# Altium schematic sheet cpu.SchDoc(cpu)
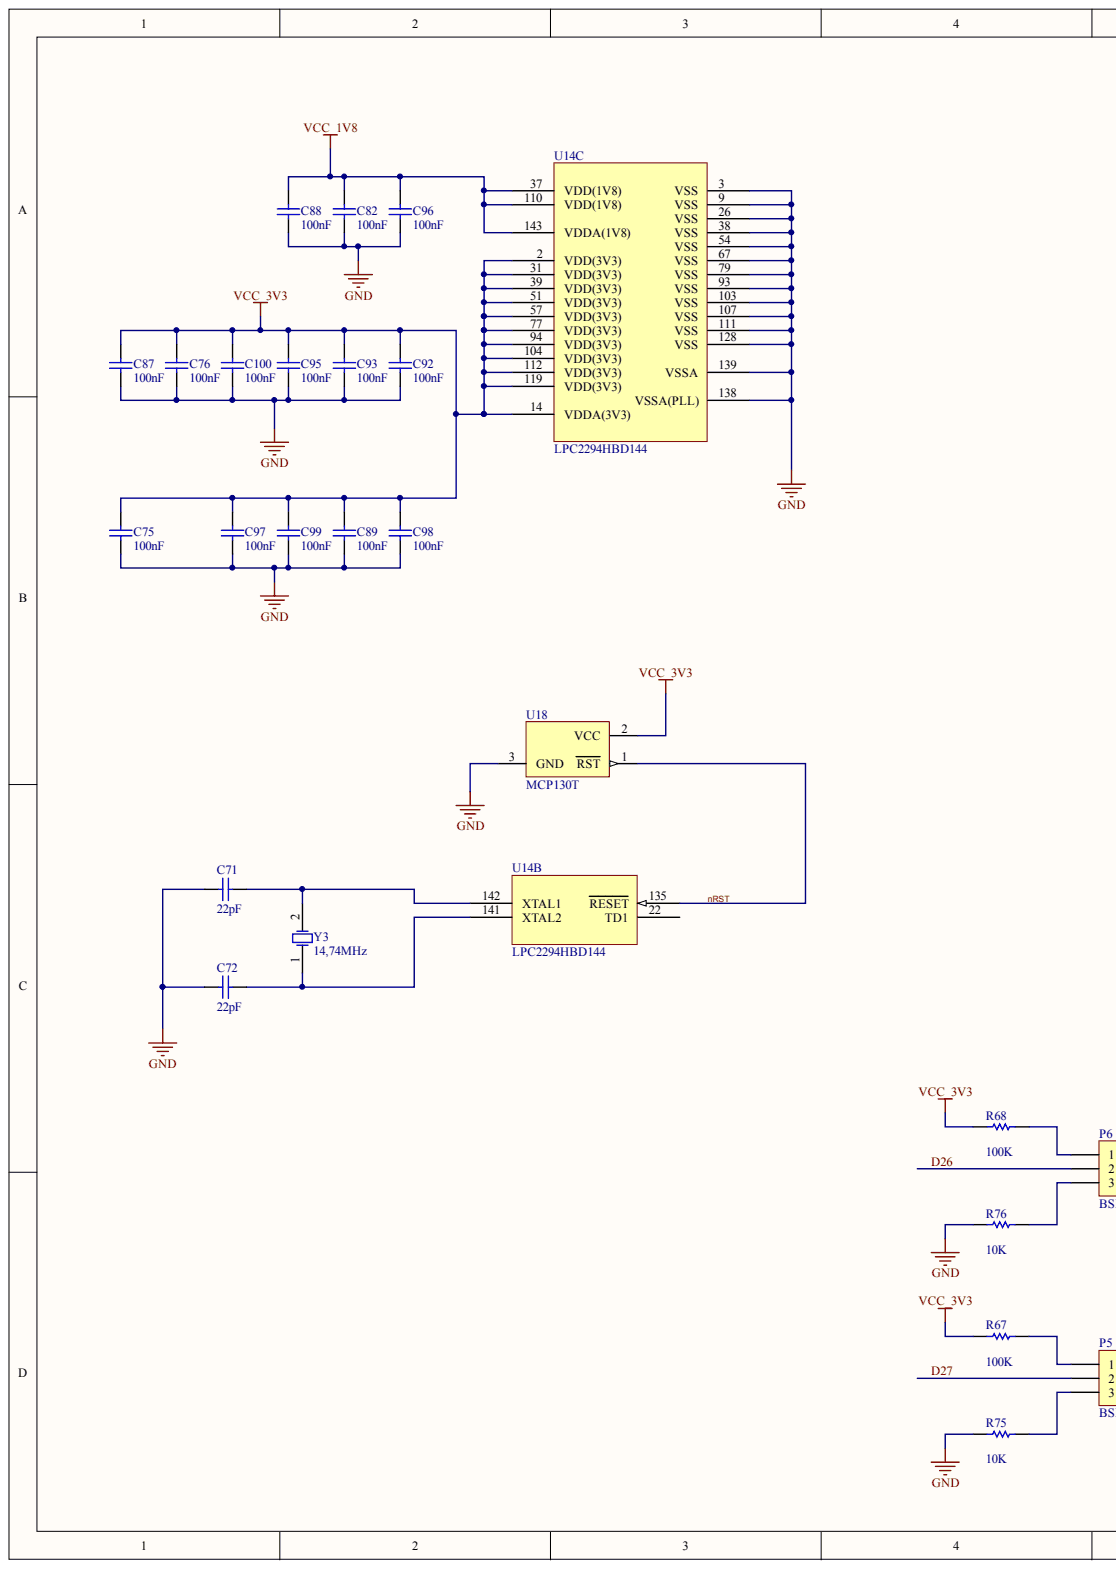
[diagram p0: cpu.SchDoc, left]
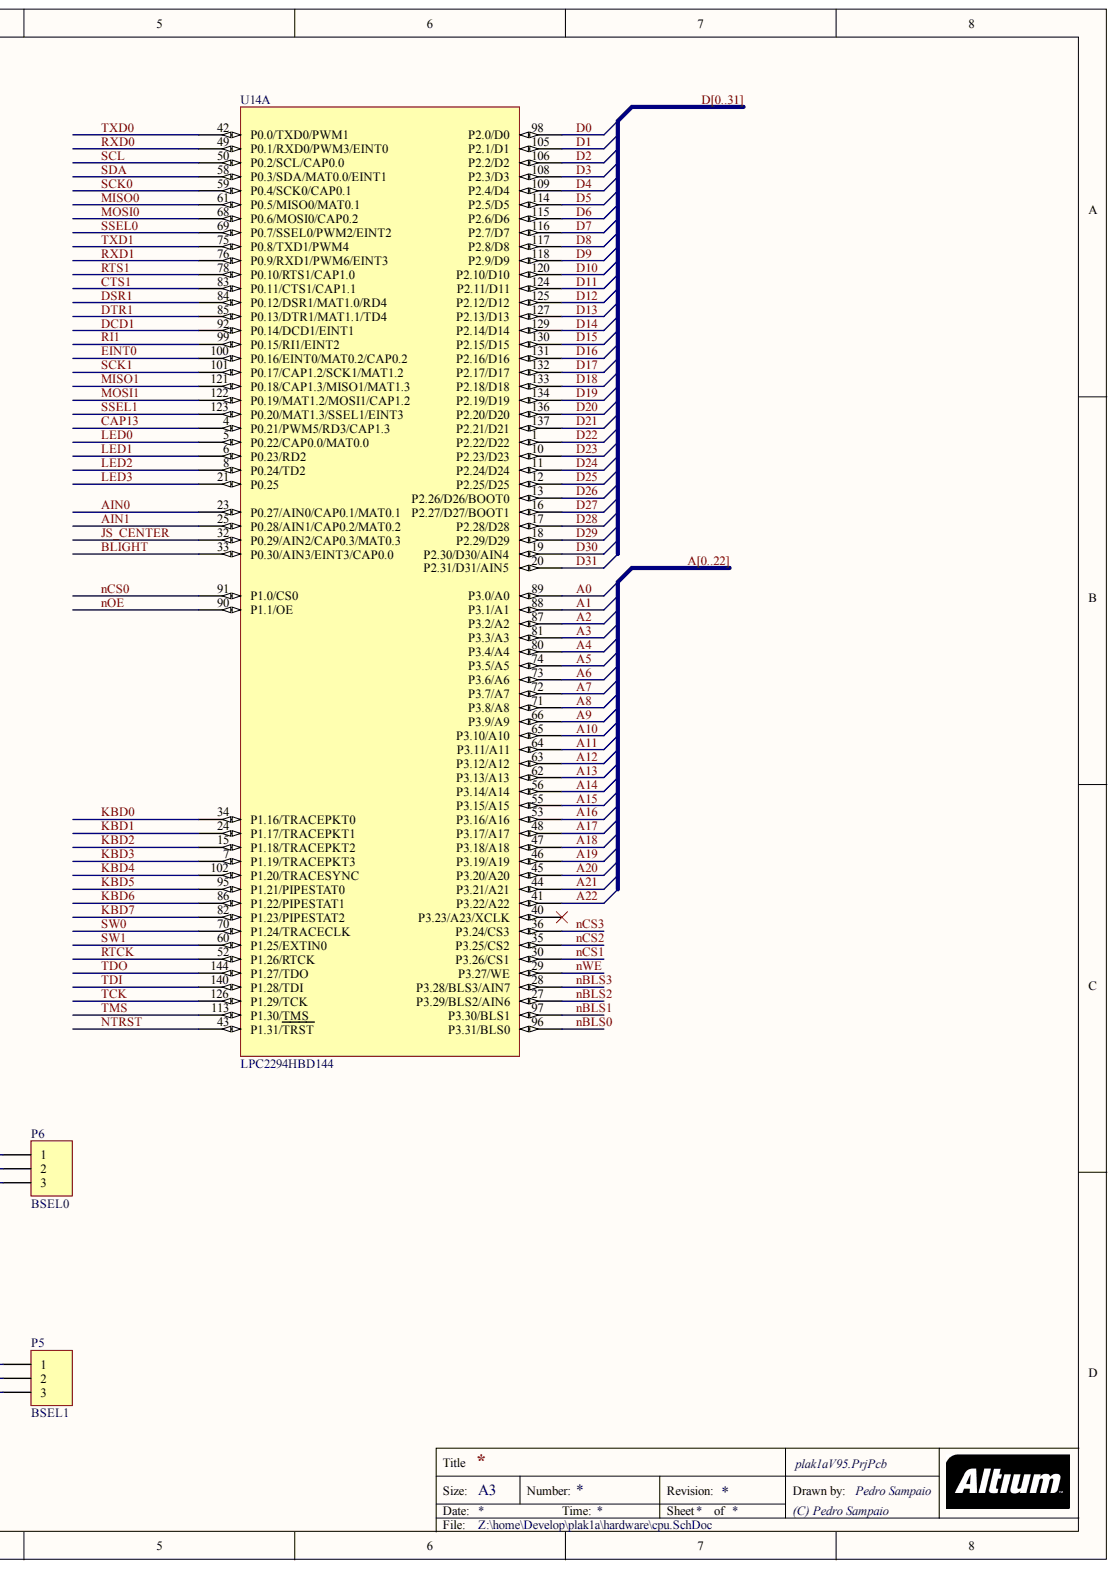
[diagram p1: cpu.SchDoc, right]

## Parts
C71  value 22pF  [0805]  (hidden comment: C0805)
C72  value 22pF  [0805]  (hidden comment: C0805)
C75  value 100nF  [0603]  (hidden comment: C0603)
C76  value 100nF  [0603]  (hidden comment: C0603)
C82  value 100nF  [0603]  (hidden comment: C0603)
C87  value 100nF  [0603]  (hidden comment: C0603)
C88  value 100nF  [0603]  (hidden comment: C0603)
C89  value 100nF  [0603]  (hidden comment: C0603)
C92  value 100nF  [0603]  (hidden comment: C0603)
C93  value 100nF  [0603]  (hidden comment: C0603)
C95  value 100nF  [0603]  (hidden comment: C0603)
C96  value 100nF  [0603]  (hidden comment: C0603)
C97  value 100nF  [0603]  (hidden comment: C0603)
C98  value 100nF  [0603]  (hidden comment: C0603)
C99  value 100nF  [0603]  (hidden comment: C0603)
C100  value 100nF  [0603]  (hidden comment: C0603)
P5  BSEL1  [HDR1X3]
P6  BSEL0  [HDR1X3]
R67  value 100K  [3216[1206]]  (hidden comment: Res Semi)
R68  value 100K  [3216[1206]]  (hidden comment: Res Semi)
R75  value 10K  [3216[1206]]  (hidden comment: Res Semi)
R76  value 10K  [3216[1206]]  (hidden comment: Res Semi)
U14  LPC2294HBD144  [SOT486-1_N]  (parts A,B,C)
U18  MCP130T  [SOT223]
Y3  14,74MHz  [CS10]

## Nets
GND: C71.1 C72.1 C75.1 C76.1 C82.1 C87.1 C88.1 C89.1 C92.1 C93.1 C95.1 C96.1 C97.1 C98.1 C99.1 C100.1 R75.1 R76.1 U14.3(VSS) U14.9(VSS) U14.26(VSS) U14.38(VSS) U14.54(VSS) U14.67(VSS) U14.79(VSS) U14.93(VSS) U14.103(VSS) U14.107(VSS) U14.111(VSS) U14.128(VSS) U14.138(VSSA(PLL)) U14.139(VSSA) U18.3
VCC_1V8: C82.2 C88.2 C96.2 U14.37(VDD(1V8)) U14.110(VDD(1V8)) U14.143(VDDA(1V8))
VCC_3V3: C75.2 C76.2 C87.2 C89.2 C92.2 C93.2 C95.2 C97.2 C98.2 C99.2 C100.2 R67.1 R68.1 U14.2(VDD(3V3)) U14.14(VDDA(3V3)) U14.31(VDD(3V3)) U14.39(VDD(3V3)) U14.51(VDD(3V3)) U14.57(VDD(3V3)) U14.77(VDD(3V3)) U14.94(VDD(3V3)) U14.104(VDD(3V3)) U14.112(VDD(3V3)) U14.119(VDD(3V3)) U18.2
A0: U14.89(P3.0/A0)  [also labelled A]
A1: U14.88(P3.1/A1)  [also labelled A]
A2: U14.87(P3.2/A2)  [also labelled A]
A3: U14.81(P3.3/A3)  [also labelled A]
A4: U14.80(P3.4/A4)  [also labelled A]
A5: U14.74(P3.5/A5)  [also labelled A]
A6: U14.73(P3.6/A6)  [also labelled A]
A7: U14.72(P3.7/A7)  [also labelled A]
A8: U14.71(P3.8/A8)  [also labelled A]
A9: U14.66(P3.9/A9)  [also labelled A]
A10: U14.65(P3.10/A10)  [also labelled A]
A11: U14.64(P3.11/A11)  [also labelled A]
A12: U14.63(P3.12/A12)  [also labelled A]
A13: U14.62(P3.13/A13)  [also labelled A]
A14: U14.56(P3.14/A14)  [also labelled A]
A15: U14.55(P3.15/A15)  [also labelled A]
A16: U14.53(P3.16/A16)  [also labelled A]
A17: U14.48(P3.17/A17)  [also labelled A]
A18: U14.47(P3.18/A18)  [also labelled A]
A19: U14.46(P3.19/A19)  [also labelled A]
A20: U14.45(P3.20/A20)  [also labelled A]
A21: U14.44(P3.21/A21)  [also labelled A]
A22: U14.41(P3.22/A22)  [also labelled A]
AIN0: U14.23(P0.27/AIN0/CAP0.1/MAT0.1)
AIN1: U14.25(P0.28/AIN1/CAP0.2/MAT0.2)
BLIGHT: U14.33(P0.30/AIN3/EINT3/CAP0.0)
CAP13: U14.4(P0.21/PWM5/RD3/CAP1.3)
CTS1: U14.83(P0.11/CTS1/CAP1.1)
D0: U14.98(P2.0/D0)  [also labelled D]
D1: U14.105(P2.1/D1)  [also labelled D]
D2: U14.106(P2.2/D2)  [also labelled D]
D3: U14.108(P2.3/D3)  [also labelled D]
D4: U14.109(P2.4/D4)  [also labelled D]
D5: U14.114(P2.5/D5)  [also labelled D]
D6: U14.115(P2.6/D6)  [also labelled D]
D7: U14.116(P2.7/D7)  [also labelled D]
D8: U14.117(P2.8/D8)  [also labelled D]
D9: U14.118(P2.9/D9)  [also labelled D]
D10: U14.120(P2.10/D10)  [also labelled D]
D11: U14.124(P2.11/D11)  [also labelled D]
D12: U14.125(P2.12/D12)  [also labelled D]
D13: U14.127(P2.13/D13)  [also labelled D]
D14: U14.129(P2.14/D14)  [also labelled D]
D15: U14.130(P2.15/D15)  [also labelled D]
D16: U14.131(P2.16/D16)  [also labelled D]
D17: U14.132(P2.17/D17)  [also labelled D]
D18: U14.133(P2.18/D18)  [also labelled D]
D19: U14.134(P2.19/D19)  [also labelled D]
D20: U14.136(P2.20/D20)  [also labelled D]
D21: U14.137(P2.21/D21)  [also labelled D]
D22: U14.1(P2.22/D22)  [also labelled D]
D23: U14.10(P2.23/D23)  [also labelled D]
D24: U14.11(P2.24/D24)  [also labelled D]
D25: U14.12(P2.25/D25)  [also labelled D]
D26: P6.2 U14.13(P2.26/D26/BOOT0)  [also labelled D]
D27: P5.2 U14.16(P2.27/D27/BOOT1)  [also labelled D]
D28: U14.17(P2.28/D28)  [also labelled D]
D29: U14.18(P2.29/D29)  [also labelled D]
D30: U14.19(P2.30/D30/AIN4)  [also labelled D]
D31: U14.20(P2.31/D31/AIN5)  [also labelled D]
DCD1: U14.92(P0.14/DCD1/EINT1)
DSR1: U14.84(P0.12/DSR1/MAT1.0/RD4)
DTR1: U14.85(P0.13/DTR1/MAT1.1/TD4)
EINT0: U14.100(P0.16/EINT0/MAT0.2/CAP0.2)
JS_CENTER: U14.32(P0.29/AIN2/CAP0.3/MAT0.3)
KBD0: U14.34(P1.16/TRACEPKT0)
KBD1: U14.24(P1.17/TRACEPKT1)
KBD2: U14.15(P1.18/TRACEPKT2)
KBD3: U14.7(P1.19/TRACEPKT3)
KBD4: U14.102(P1.20/TRACESYNC)
KBD5: U14.95(P1.21/PIPESTAT0)
KBD6: U14.86(P1.22/PIPESTAT1)
KBD7: U14.82(P1.23/PIPESTAT2)
LED0: U14.5(P0.22/CAP0.0/MAT0.0)
LED1: U14.6(P0.23/RD2)
LED2: U14.8(P0.24/TD2)
LED3: U14.21(P0.25)
MISO0: U14.61(P0.5/MISO0/MAT0.1)
MISO1: U14.121(P0.18/CAP1.3/MISO1/MAT1.3)
MOSI0: U14.68(P0.6/MOSI0/CAP0.2)
MOSI1: U14.122(P0.19/MAT1.2/MOSI1/CAP1.2)
NBLS0: U14.96(P3.31/BLS0)
NBLS1: U14.97(P3.30/BLS1)
NBLS2: U14.27(P3.29/BLS2/AIN6)
NBLS3: U14.28(P3.28/BLS3/AIN7)
NCS0: U14.91(P1.0/CS0)
NCS1: U14.30(P3.26/CS1)
NCS2: U14.35(P3.25/CS2)
NCS3: U14.36(P3.24/CS3)
NOE: U14.90(P1.1/OE)
NRST: U14.135(RESET) U18.1
NTRST: U14.43(P1.31/TRST)
NWE: U14.29(P3.27/WE)
RI1: U14.99(P0.15/RI1/EINT2)
RTCK: U14.52(P1.26/RTCK)
RTS1: U14.78(P0.10/RTS1/CAP1.0)
RXD0: U14.49(P0.1/RXD0/PWM3/EINT0)
RXD1: U14.76(P0.9/RXD1/PWM6/EINT3)
SCK0: U14.59(P0.4/SCK0/CAP0.1)
SCK1: U14.101(P0.17/CAP1.2/SCK1/MAT1.2)
SCL: U14.50(P0.2/SCL/CAP0.0)
SDA: U14.58(P0.3/SDA/MAT0.0/EINT1)
SSEL0: U14.69(P0.7/SSEL0/PWM2/EINT2)
SSEL1: U14.123(P0.20/MAT1.3/SSEL1/EINT3)
SW0: U14.70(P1.24/TRACECLK)
SW1: U14.60(P1.25/EXTIN0)
TCK: U14.126(P1.29/TCK)
TDI: U14.140(P1.28/TDI)
TDO: U14.144(P1.27/TDO)
TMS: U14.113(P1.30/TMS)
TXD0: U14.42(P0.0/TXD0/PWM1)
TXD1: U14.75(P0.8/TXD1/PWM4)
NetC71_2: C71.2 U14.142(XTAL1) Y3.2
NetC72_2: C72.2 U14.141(XTAL2) Y3.1
NetP5_1: P5.1 R67.2
NetP5_3: P5.3 R75.2
NetP6_1: P6.1 R68.2
NetP6_3: P6.3 R76.2

Not connected: U14 22(TD1) 40(P3.23/A23/XCLK)

## Library data of the parts
C71 C72 = C0805: Ceramic Chip Capacitor - Standard; Note: Footprint corresponds to dimensions for bulk cassette packaging. This case size has tightened tolerances.; Code_IPC: 2012[0805]; Code_JEITA: 0805; Component Kind: Standard; ComponentLink1Description: Manufacturer Link; ComponentLink1URL: http://www.kemet.com/; ComponentLink2Description: Datasheet; ComponentLink2URL: http://www.kemet.com/kemet/web/homepage/kechome.nsf/vapubfilesname/F3102TA.pdf/$file/F3102TA.pdf; DatasheetDocument: Mar-2001; Farnell: 9406263; LatestRevisionDate: 19-Mar-2004; LatestRevisionNote: Silkscreen size reduced.; Library Name: KEMET Chip Capacitor.IntLib; PackageDocument: Mar-2001; PackageReference: 0805; Published: 17-Jul-2002; Signal Integrity: C0805; Simulation: CAP; Publisher: Altium Limited
C75 C76 C82 C87-C89 C92 C93 C95-C100 = C0603: Ceramic Chip Capacitor - Standard; Note: Footprint corresponds to dimensions for bulk cassette packaging. This case size has tightened tolerances.; Code_IPC: 1608 [0603]; Code_JEITA: 0603; Component Kind: Standard; ComponentLink1Description: Manufacturer Link; ComponentLink1URL: http://www.kemet.com/; ComponentLink2Description: Datasheet; ComponentLink2URL: http://www.kemet.com/kemet/web/homepage/kechome.nsf/vapubfilesname/F3102TA.pdf/$file/F3102TA.pdf; DatasheetDocument: Mar-2001; Farnell: 1301716; LatestRevisionDate: 19-Mar-2004; LatestRevisionNote: Silkscreen size reduced.; Library Name: KEMET Chip Capacitor.IntLib; PackageDocument: Mar-2001; PackageReference: 0603; Published: 17-Jul-2002; Signal Integrity: C0603; Simulation: CAP; Publisher: Altium Limited
P5 P6 = Header 3: Header, 3-Pin; Component Kind: Standard; LatestRevisionDate: 17-Jul-2002; LatestRevisionNote: Re-released for DXP Platform.; Library Name: Miscellaneous Connectors.IntLib; Signal Integrity: Connector; Publisher: Altium Limited
R67 R68 R75 R76 = Res Semi: Semiconductor Resistor; Component Kind: Standard; LatestRevisionDate: 17-Jul-2002; LatestRevisionNote: Re-released for DXP Platform.; Library Name: Miscellaneous Devices.IntLib; PackageReference: AXIAL-0.5; Published: 8-Jun-2000; Signal Integrity: Res Semi; Simulation: RES; Publisher: Altium Limited
  R67 R68: Farnell: 9240764
  R75 R76: Farnell: 9240640
U14 = LPC2294HBD144: ARM7 16/32-Bit Microcontroller, 256KB Flash, 16KB RAM, 4 Channel CAN, 144-Lead LQFP; Code_IEC: 136E23; Code_JEDEC: MS-026; Component Kind: Standard; ComponentLink1Description: Manufacturer Link; ComponentLink1URL: http://www.nxp.com/; ComponentLink2Description: Datasheet; ComponentLink2URL: http://www.standardics.nxp.com/products/lpc2000/datasheet/lpc2292.lpc2294.pdf; DatasheetVersion: rev.06, Jul-2007; Library Name: NXP LPC2000.IntLib; PackageDescription: 144-Pin Plastic Low Profile Quad Flat Pack 0.5 mm Pitch; PackageReference: SOT486-1; PackageVersion: Feb-2003; PCB3D: LPC2294-QFP144; Published: 16-Oct-2006; Publisher: Altium Limited
U18 = DS1810-10: 5V EconoReset with Push-Pull Output; Component Kind: Standard; ComponentLink1Description: Manufacturer Link; ComponentLink1URL: http://www.maxim-ic.com/; ComponentLink2Description: Datasheet; ComponentLink2URL: http://pdfserv.maxim-ic.com/en/ds/DS1810.pdf; DatasheetVersion: 26-Feb-1998; Farnell: 1467833; LatestRevisionDate: 17-Jul-2002; LatestRevisionNote: Re-released for DXP Platform.; Library Name: Dallas Power Mgt Voltage Detector.IntLib; PackageDescription: TO, Flat Index; 3 In-Line, Axial Leads; Body Dia. 4.7mm; Leads 0.56 x 0.51 mm (max); PackageReference: TO-92; PackageVersion: Feb-1999; Published: 13-Oct-2000; Publisher: Altium Limited
Y3 = HC49/4H_SMX: Surface Mount Quartz Crystal; Note: PCB layout complies with manufacturer's recommendation.; Component Kind: Standard; ComponentLink1Description: Manufacturer Link; ComponentLink1URL: http://www.cmac.com/mt/; ComponentLink2Description: Datasheet; ComponentLink2URL: http://www.cmac.com/mt/databook/crystals/smd/hc49_4h_smx.pdf; DatasheetDocument: 18-Oct-1999; Farnell: 1457188; Library Name: C-MAC Crystal Oscillator.IntLib; PackageDocument: 18-Oct-1999; PackageReference: HC49/4H_SMX; Published: 17-Jul-2002; Publisher: Altium Limited
